# Altium schematic sheet ESP32.SchDoc(ESP32)
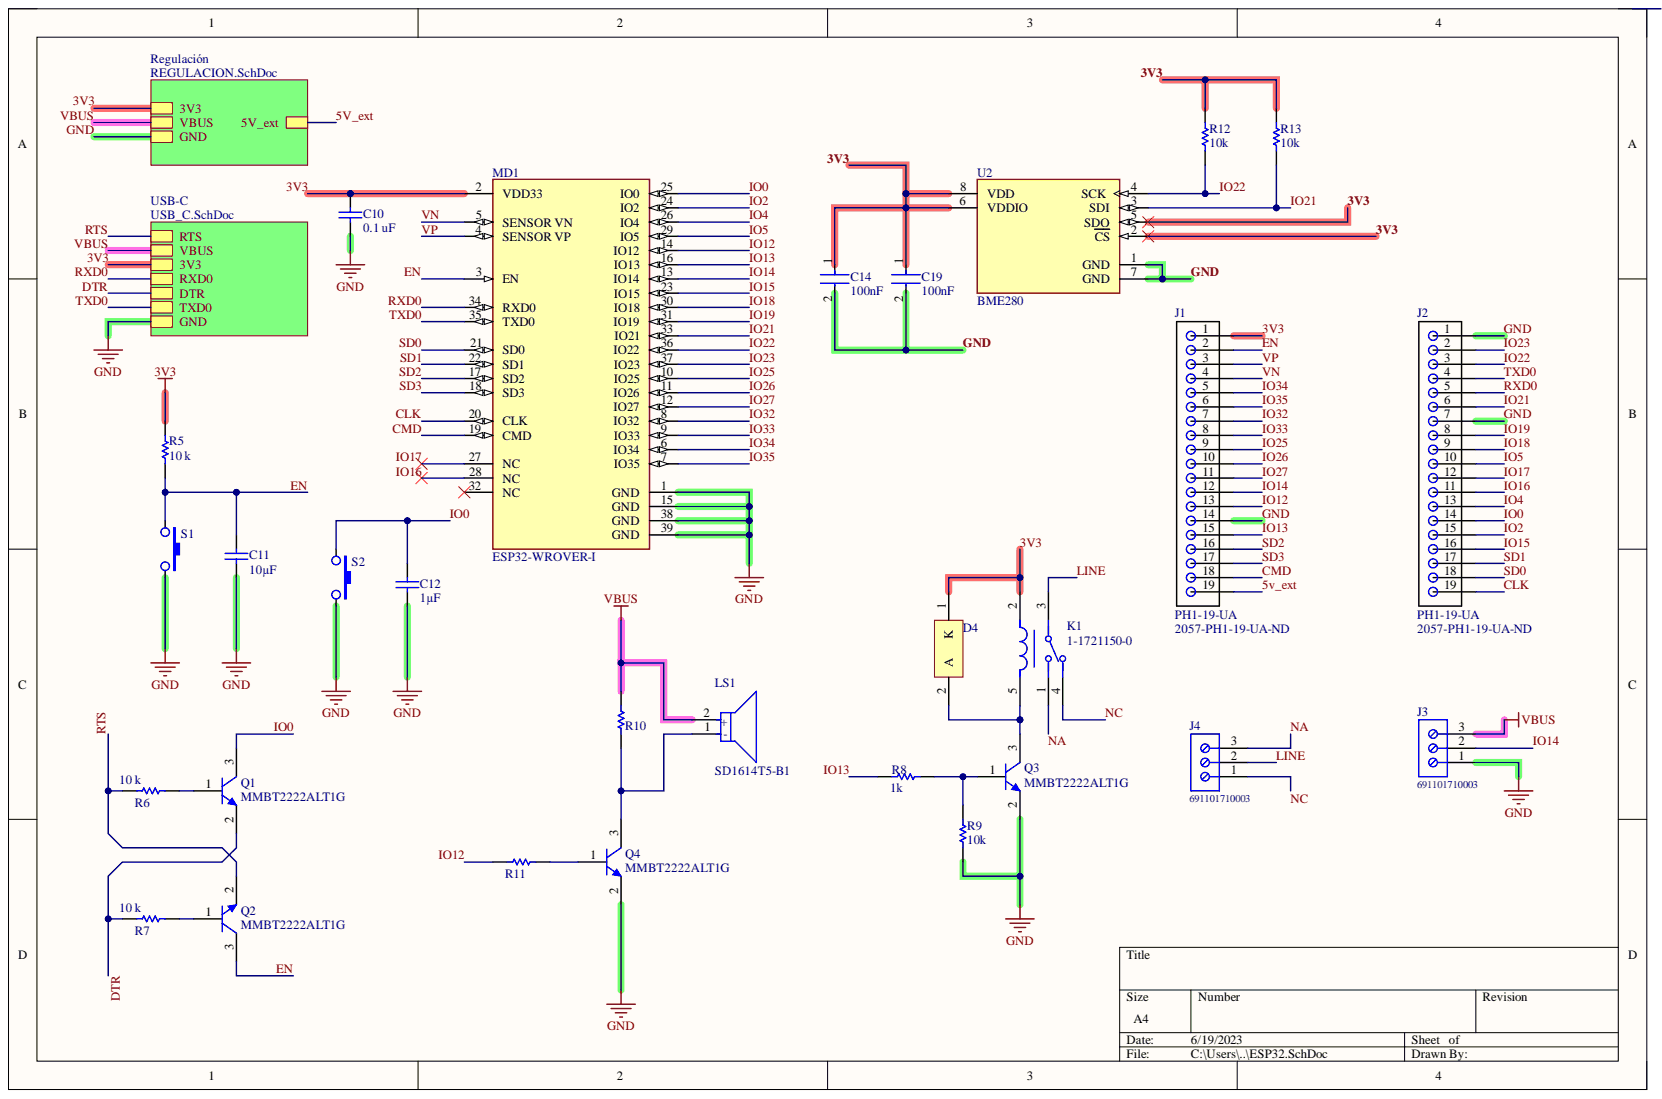
[diagram p0: ESP32.SchDoc]

## Parts
C10  0.1 uF  [CAPC2013X94X50ML20T25]
C11  10µF  [CAPC2013X145X50ML20T25]
C12  1µF  [CAPC2013X145X50ML20T25]
C14  100nF  [FP-C0805C-DN-IPC_A]
C19  100nF  [FP-C0805C-DN-IPC_A]
D4  MBR120ESFT1G  [ONSC-SOD-123FL-2-498_V]  (value not drawn)
J1  PH1-19-UA  Supplier Part Number 1: 2057-PH1-19-UA-ND  [PH1-19-UA]
J2  PH1-19-UA  Supplier Part Number 1: 2057-PH1-19-UA-ND  [PH1-19-UA]
J3  691101710003  [691101710003]
J4  691101710003  [691101710003]
K1  1-1721150-0  [FP-1-1721150-0-MFG]
LS1  SD1614T5-B1  [FP-SD1614T5-B1-MFG]
MD1  ESP32-WROVER-I  [FP-ESP32-WROVER-I-MFG]
Q1  MMBT2222ALT1G  [ONSC-SOT-23-3-318-08_V]
Q2  MMBT2222ALT1G  [ONSC-SOT-23-3-318-08_V]
Q3  MMBT2222ALT1G  [ONSC-SOT-23-3-318-08_V]
Q4  MMBT2222ALT1G  [ONSC-SOT-23-3-318-08_V]
R5  10 k  [RESC2013X60X30ML20T20]
R6  10 k  [RESC2013X60X30ML20T20]
R7  10 k  [RESC2013X60X30ML20T20]
R8  1k  [RESC2013X60X30ML20T20]
R9  10k  [RESC2013X60X30ML20T20]
R10  ERJ-P06J102V  [RESC2013X70X40ML20T20]  (value not drawn)
R11  RK73B2ATTD103J  [RESC2013X60X30ML20T20]  (value not drawn)
R12  10k  [RESC2013X60X40ML20T25_A]
R13  10k  [RESC2013X60X40ML20T25_A]
S1  PTS820J25MSMTRLFS  [SMT_SW_PTS_820]  (value not drawn)
S2  IO0  [SMT_SW_PTS_820]  (value not drawn)
U2  BME280  [FP-BME280-MFG]

## Nets
3V3: C10.2 C14.1 C19.1 D4.1 J1.1 K1.2 MD1.2(VDD33) R5.2 R12.2 R13.2 U2.2(CS) U2.5(SDO) U2.6(VDDIO) U2.8(VDD)
5v_ext: J1.19
CLK: J2.19 MD1.20(CLK)
CMD: J1.18 MD1.19(CMD)
DTR: Q1.2 R7.2
EN: C11.2 J1.2 MD1.3(EN) Q2.3 R5.1 S1.1
GND: C10.1 C11.1 C12.1 C14.2 C19.2 J1.14 J2.1 J2.7 J3.1 MD1.1(GND) MD1.15(GND) MD1.38(GND) MD1.39(GND) Q3.2 Q4.2 R9.2 S1.2 S2.2 U2.1(GND) U2.7(GND)
IO0: C12.2 J2.14 MD1.25(IO0) Q1.3 S2.1
IO2: J2.15 MD1.24(IO2)
IO4: J2.13 MD1.26(IO4)
IO5: J2.10 MD1.29(IO5)
IO12: J1.13 MD1.14(IO12) R11.1
IO13: J1.15 MD1.16(IO13) R8.1
IO14: J1.12 J3.2 MD1.13(IO14)
IO15: J2.16 MD1.23(IO15)
IO16: J2.11 MD1.28(NC)
IO17: J2.12 MD1.27(NC)
IO18: J2.9 MD1.30(IO18)
IO19: J2.8 MD1.31(IO19)
IO21: J2.6 MD1.33(IO21) R13.1 U2.3(SDI)
IO22: J2.3 MD1.36(IO22) R12.1 U2.4(SCK)
IO23: J2.2 MD1.37(IO23)
IO25: J1.9 MD1.10(IO25)
IO26: J1.10 MD1.11(IO26)
IO27: J1.11 MD1.12(IO27)
IO32: J1.7 MD1.8(IO32)
IO33: J1.8 MD1.9(IO33)
IO34: J1.5 MD1.6(IO34)
IO35: J1.6 MD1.7(IO35)
LINE: J4.2 K1.3
NA: J4.3 K1.1
NC: J4.1 K1.4
RTS: Q2.2 R6.2
RXD0: J2.5 MD1.34(RXD0)
SD0: J2.18 MD1.21(SD0)
SD1: J2.17 MD1.22(SD1)
SD2: J1.16 MD1.17(SD2)
SD3: J1.17 MD1.18(SD3)
TXD0: J2.4 MD1.35(TXD0)
VBUS: J3.3 LS1.2 R10.2
VN: J1.4 MD1.5(SENSOR_VN)
VP: J1.3 MD1.4(SENSOR_VP)
NetD4_2: D4.2 K1.5 Q3.3
NetLS1_1: LS1.1 Q4.3 R10.1
NetMD1_32: MD1.32(NC)
NetQ1_1: Q1.1 R6.1
NetQ2_1: Q2.1 R7.1
NetQ3_1: Q3.1 R8.2 R9.1
NetQ4_1: Q4.1 R11.2

## Library data of the parts
C10 = CMP-2000-05016-1: Chip Capacitor, Ceramic, 0.1 uF, 10%, 50 V, 0805, RoHS, Tape and Reel; Manufacturer: AVX; Capacitance: 100nF; Dielectric: X7R; Rated Voltage: 50V; Case Size/Dimension: 0805; Component Kind: Standard; Datasheet URL: http://www.farnell.com/datasheets/319609.pdf; Datasheet Version: Sep-07; Library Name: Altium Content Vault; Manufacturer URL: http://www.avx.com/; Tolerance (%): ±10%
C11 C12 = CMP-2007-01082-1: 1µF ±10% 16V Ceramic Capacitor X7R 0805 (2012 Metric); Manufacturer: Kemet; Part Number: C0805C105K4RAC7800; Component Kind: Standard; Library Name: Altium Content Vault
C14 C19 = CMP-2007-00279-2: CAP CER 0.1UF 50V X7R 0805; Manufacturer: KEMET; Manufacturer Part Number: C0805C104K5RACTU; Capacitance: 0.10 μF; Material: Ceramic; Temperature Coefficient: 30ppm/°C; Tolerance: 0.1; Value: 0.10 μF; Automotive: No; Category: Cap; Component Kind: Standard; ComponentLink2Description: Datasheet; ComponentLink2URL: https://content.kemet.com/datasheets/KEM_C1003_C0G_SMD.pdf; Device Class L1: Passive Components; Device Class L2: Capacitors; Device Class L3: Ceramic Capacitors; Digikey Description: CAP CER 0.1UF 50V X7R 0805; Height: 0.88mm; Ipc Land Pattern Name: CAPC200125X78; Lead Free: Yes; Library Name: Altium Content Vault; Mouser Description: Cap Ceramic 0.1uF 50V X7R 10% SMD 2.0 x 1.2 mm 125 C Paper T/R; Octopart: https://octopart.com/c0805c104k5ractu-kemet-143926; Package: C0805C-DN; RoHS: TRUE; Temperature: -55°C to +125°C; Temperature Characteristic: X7R; Temperature Range High: +125°C; Temperature Range Low: -55°C; Voltage Rating: 50 V
D4 = CMP-1055-00434-1: Schottky Power Rectifier, 2-Pin SOD-123, Pb-Free, Tape and Reel; Manufacturer: On Semiconductor; Configuration: Single; IFSM Max (A): 40; Component Kind: Standard; ComponentLink1Description: Manufacturer URL; ComponentLink1URL: http://www.onsemi.com/; ComponentLink2Description: Datasheet; ComponentLink2URL: http://www.onsemi.cn/pub_link/Collateral/MBR120ESFT1-D.PDF; ComponentLink3Description: Package Specification; ComponentLink3URL: http://www.onsemi.com/pub_link/Collateral/498-01.PDF; DatasheetVersion: Rev. 4; Library Name: Altium Content Vault; Mounting Technology: Surface Mount; PackageDescription: 2-Pin SOD, Body 1.65 x 2.7 mm; PackageReference: SOD-123FL-2-498; PackageVersion: Rev. D, 05/2013; Packing: Tape and Reel; PartNumber: MBR120ESFT1G; RoHS: TRUE
J1 J2 = PH1-19-UA: Connector Header Through Hole 19 position 0.100" (2.54mm); Component Kind: Standard; Supplier 1: Digi-Key; Library Name: Isidro_Library.SchLib
J3 J4 = CMP-1502-03257-1: Series 101 - 5.00 mm Horizontal Entry Modular with Pressure Clamp WR-TBL, 3 pin; Manufacturer: Wurth Elektronik; Pin Number: 3; Pitch: 5.00mm; Component Kind: Standard; Datasheet URL: http://katalog.we-online.de/em/datasheet/6911017100xx.pdf; Library Name: Altium Content Vault; Manufacturer URL: http://www.we-online.de; Mounting Technology: THT; Operating Temperature: -40to105degC; Package Reference: 691101710003; Packaging: Box; Part Number: 691101710003; Publisher: WurthElektronik
K1 = CMP-03395-000112-1: RELAY GEN PURPOSE SPDT 10A 5V; Manufacturer: TE; Coil Power: 360mW; Coil Resistance: 70Ω; Coil Type: Non-Latching; Number Of Contacts: 1; Standoff Height: 0.2mm; Ambient Temperature Range High: +70°C; Ambient Temperature Range Low: -30°C; Automotive: No; Category: Relay; Coil Voltage: 5V; Component Kind: Standard; ComponentLink2Description: Datasheet; ComponentLink2URL: https://www.te.com/usa-en/product-1-1721150-0.html?te_bu=Cor&te_type=other&te_campaign=oct_glo_cor-oct-global-oth-prtnr-fy19-oct-octo-buy-bom_sma-298_2&elqCampaignId=35216; Current Rating: 10A; Device Class L1: Electromechanical; Device Class L2: Relays; Device Class L3: Power Relays; Digikey Description: RELAY GEN PURPOSE SPDT 10A 5V; Height: 16.3mm; Lead Free: Yes; Library Name: Altium Content Vault; Manufacturer Part Number: 1-1721150-0; Octopart: https://octopart.com/1-1721150-0-te+connectivity-41294869; Package: 1-1721150-0; RoHS: TRUE; Switching Current: 10A; Throw Configuration: SPDT
LS1 = CMP-08413-000012-1: AUDIO MAGNETIC XDCR 3-6V TH; Frequency: 2.048kHz; Max Supply Current: 80mA; Number of Terminals/Pins: 2; Operating Supply Voltage: 5V; Self Resonant Frequency: 2.05kHz; Washable: No; Component Kind: Standard; Diameter: 16mm; Height: 14mm; Library Name: Altium Content Vault; Max Operating Temperature: 70°C; Min Operating Temperature: -10°C; Mount: Through Hole; Number of Pins: 2; Operating Supply Current: 80mA; Package Quantity: 480; Packaging: Tray; Sensing Method: Magnetic; Series: SD; Termination: PC Pins; Voltage Rating (DC): 5V
MD1 = CMP-194065-000001-1: SMD MODULE, ESP32-D0WDQ6, 32MBIT; Manufacturer: Espressif; Data Rate: 150Mbits/s; Interface: I2C,SPI,UART,I2S,Other; Max Frequency: 2484MHz; Max Supply Voltage: 3.6V; Min Supply Voltage: 2.3V; Nominal Supply Current: 500mA; Number Of Adc Channels: 16; Number Of Gpio: 20; Sensitivity: -98dBm; Automotive: No; Category: UNK; Component Kind: Standard; ComponentLink2Description: Datasheet; ComponentLink2URL: https://www.espressif.com/sites/default/files/documentation/esp32-wrover_datasheet_en.pdf; Device Class L1: Integrated Circuits (ICs); Device Class L2: RF Semiconductors and Devices; Device Class L3: Transceivers; Digikey Description: SMD MODULE, ESP32-D0WDQ6, 32MBIT; Height: 3.4mm; Lead Free: Yes; Library Name: Altium Content Vault; Manufacturer Part Number: ESP32-WROVER-I; Octopart: https://octopart.com/esp32-wrover-i-espressif+systems-89171349; Package: ESP32-WROVER-I; RoHS: TRUE; Temperature: -40°C to +85°C; Temperature Range High: +85°C; Temperature Range Low: -40°C
Q1-Q4 = CMP-1048-00538-1: General Purpose Transistor, NPN Silicon, 3-Pin SOT-23, Pb-Free, Tape and Reel; Manufacturer: On Semiconductor; fT Min (MHz): 300; IC Continuous (A): 0.6; Component Kind: Standard; ComponentLink1Description: Manufacturer URL; ComponentLink1URL: http://www.onsemi.com/; ComponentLink2Description: Datasheet; ComponentLink2URL: http://www.onsemi.cn/pub_link/Collateral/MMBT2222LT1-D.PDF; ComponentLink3Description: Package Specification; ComponentLink3URL: http://www.onsemi.cn/pub_link/Collateral/318-08.PDF; DatasheetVersion: Rev. 10; Library Name: Altium Content Vault; Mounting Technology: Surface Mount; PackageDescription: 3-Pin Small Outline Transistor, Body 2.92 x 1.3 mm, Pitch 0.95 mm; PackageReference: SOT-23-3-318-08; PackageVersion: Rev. AP, 11/2009; Packing: Tape and Reel; PartNumber: MMBT2222ALT1G; Polarity: NPN; RoHS: TRUE
R5-R9 = CMP-2001-04657-1: 10 kOhms ±5% 0.25W, 1/4W Chip Resistor 0805 (2012 Metric) Automotive AEC-Q200, Moisture Resistant Thick Film; Manufacturer: KOA Speer; Part Number: RK73B2ATTD103J; Component Kind: Standard; Library Name: Altium Content Vault
R10 = CMP-2001-00477-1: RES SMD 1K OHM 5% 1/2W 0805; Manufacturer: Panasonic; Component Kind: Standard; Library Name: Altium Content Vault; Part Number: ERJ-P06J102V
R11 = CMP-2001-04657-1: 10 kOhms ±5% 0.25W, 1/4W Chip Resistor 0805 (2012 Metric) Automotive AEC-Q200, Moisture Resistant Thick Film; Manufacturer: KOA Speer; Component Kind: Standard; Library Name: Altium Content Vault; Part Number: RK73B2ATTD103J
R12 R13 = CMP-2001-00582-2: Metal Film Chip Resistor, High Reliability Type, 0.125 W, +/- 0.1%, -55 to 155 degC, 0805 (2012 Metric), RoHS, Tape and Reel; Brand: Panasonic; Power Rating: 125mW(1/8W); Resistance: 10kOhms; Temperature Coefficient: 25PPM/C; Voltage Rating: 100V; Case Code - in: 0805; Case Code - mm: 2012; Component Kind: Standard; Datasheet URL: https://industrial.panasonic.com/cdbs/www-data/pdf/RDM0000/AOA0000C307.pdf; Datasheet Version: 02/2016; Factory Pack Quantity: 5000; Height: 0.5mm; Length: 2mm; Library Name: Altium Content Vault; Manufacturer: Panasonic; Manufacturer URL: http://www.panasonic.com/; Mounting Technology: SurfaceMount; Operating Temperature Range: -55Cto+155C; Package / Case: 0805(2012metric); Package Description: 2-Pin Surface Mount Device, Body 2 x 1.25 mm; Package Reference: 0805; Packaging: Reel; Product: ThinFilmResistorsSMD; Product Category: ThinFilmResistors-SMD; RoHS: Details; Series: ERA-6A; Termination Style: SMD/SMT; Tolerance: 0.1%; Type: MetalFilmChipResistors; Width: 1.25mm
S2 = PTS820J25MSMTRLFS: Component Kind: Standard; Library Name: SnapEDAsch.SchLib
U2 = CMP-2000-05073-3: SENSOR PRESSURE HUMIDITY TEMP; Accuracy: 3%; Output Type: SPI; Response Time: 1s; Sensor Type: Temperature; Case/Package: LGA; Component Kind: Standard; Lead Free: Lead Free; Library Name: Altium Content Vault; Max Operating Temperature: 85°C; Min Operating Temperature: -40°C; Mount: Surface Mount; Number of Pins: 8; Packaging: Cut Tape
S1 = PTS820J25MSMTRLFS: Component Kind: Standard; Library Name: SnapEDAsch.SchLib
